# Altium schematic sheet CHIPHOME Y17BP01 Peripherals.SchDoc
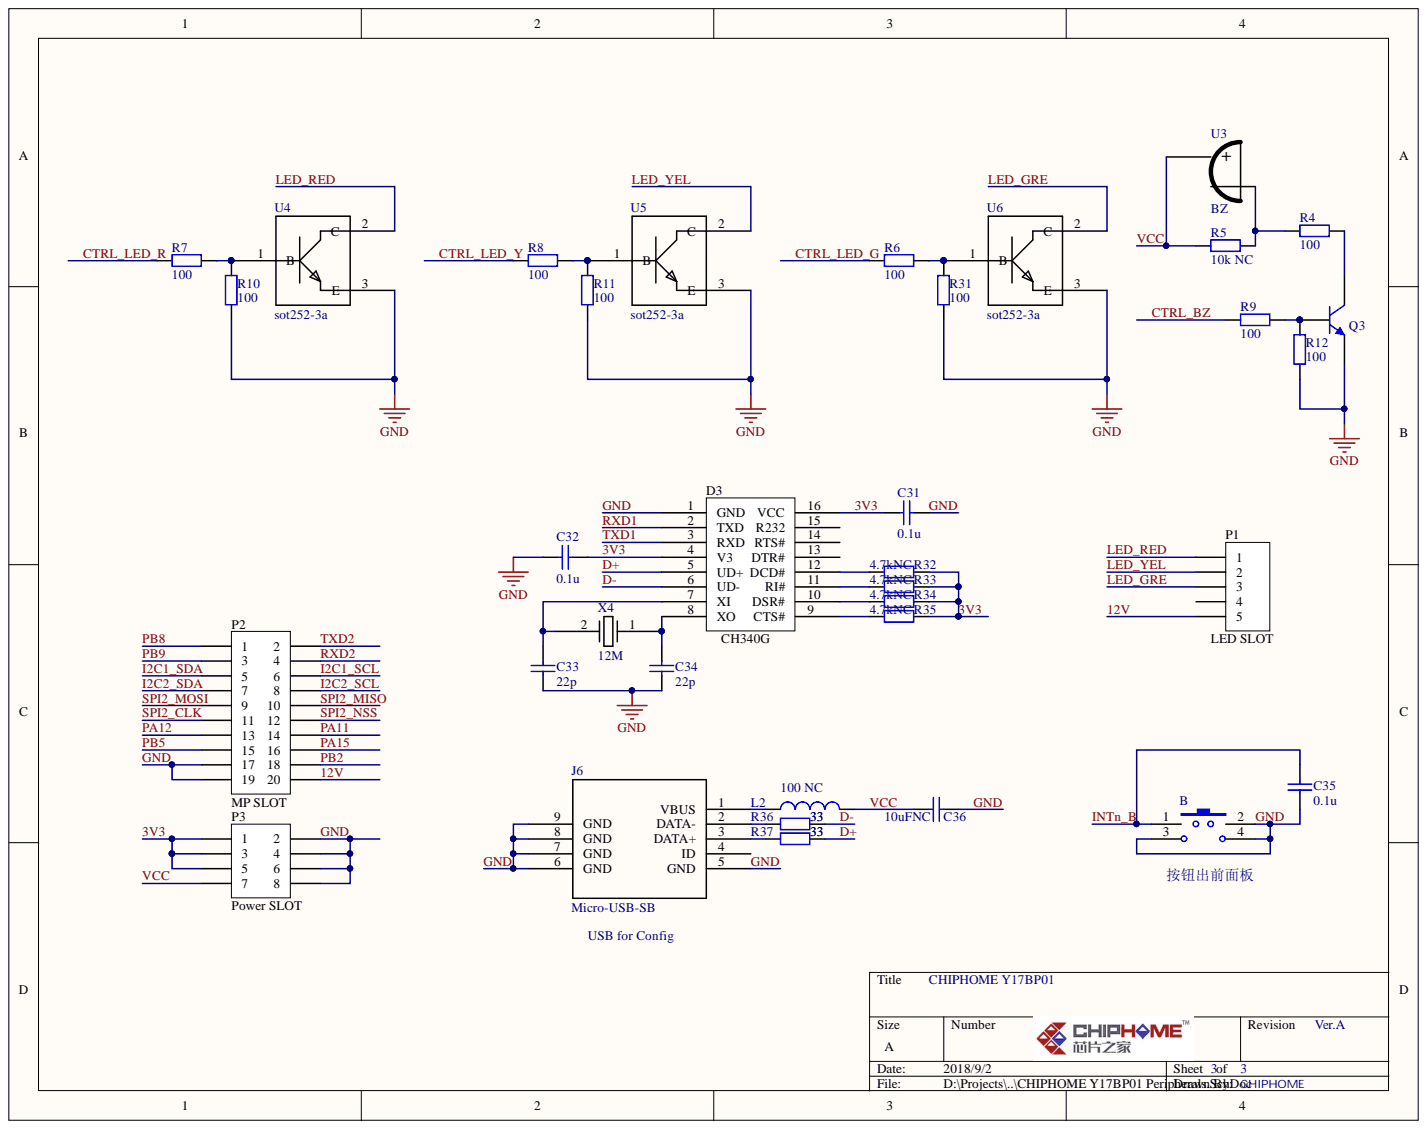
[diagram p0: CHIPHOME Y17BP01 Peripherals.SchDoc]

## Parts
B  switch-4p-dip-c  [switch-4p-dip-c]  (value not drawn)
C31  0.1u  [sc0603a]
C32  0.1u  [sc0603a]
C33  22p  [sc0603a]
C34  22p  [sc0603a]
C35  0.1u  [sc0603a]
C36  10uFNC  [sc0805a]
D3  CH340G  [sop16-50-062a]
J6  Micro-USB-SB  [susb5-0508a]
L2  100 NC  value 100  [sl0603a]
P1  LED SLOT  [sip5-100-H-0105a]
P2  MP SLOT  [HDR2X10]
P3  Power SLOT  [HDR2X4]
Q3  三极管  [SOT-23B_M]  (value not drawn)
R4  100  [sr0603a]
R5  10k NC  value 10k  [sr0603a]
R6  100  [sr0603a]
R7  100  [sr0603a]
R8  100  [sr0603a]
R9  100  [sr0603a]
R10  100  [sr0603a]
R11  100  [sr0603a]
R12  100  [sr0603a]
R31  100  [sr0603a]
R32  4.7kNC  [sr0603a]
R33  4.7kNC  [sr0603a]
R34  4.7kNC  [sr0603a]
R35  4.7kNC  [sr0603a]
R36  33  [L0603]
R37  33  [L0603]
U3  BZ  [buzzer2-065-12a]
U4  sot252-3a  [sot252-3a]
U5  sot252-3a  [sot252-3a]
U6  sot252-3a  [sot252-3a]
X4  12M  [sx2-0412a]

## Nets
3V3: C31.1 C32.2 D3.4(V3) D3.16(VCC) P3.1 P3.3 P3.5 R32.2 R33.2 R34.2 R35.2
12V: P1.5 P2.20
CTRL_BZ: R9.1
CTRL_LED_G: R6.1
CTRL_LED_R: R7.1
CTRL_LED_Y: R8.1
D+: D3.5(UD+) R37.2
D-: D3.6(UD-) R36.2
GND: B.2 B.3 B.4 C31.2 C32.1 C33.2 C34.2 C35.1 C36.1 D3.1(GND) J6.5(GND) J6.6(GND) J6.7(GND) J6.8(GND) J6.9(GND) P2.17 P2.19 P3.2 P3.4 P3.6 P3.8 Q3.2 R10.1 R11.1 R12.1 R31.1 U4.3 U5.3 U6.3
I2C1_SCL: P2.6
I2C1_SDA: P2.5
I2C2_SCL: P2.8
I2C2_SDA: P2.7
INTn_B: B.1 C35.2
LED_GRE: P1.3 U6.2
LED_RED: P1.1 U4.2
LED_YEL: P1.2 U5.2
PA11: P2.14
PA12: P2.13
PA15: P2.16
PB2: P2.18
PB5: P2.15
PB8: P2.1
PB9: P2.3
RXD1: D3.2(TXD)
RXD2: P2.4
SPI2_CLK: P2.11
SPI2_MISO: P2.10
SPI2_MOSI: P2.9
SPI2_NSS: P2.12
TXD1: D3.3(RXD)
TXD2: P2.2
VCC: C36.2 L2.2 P3.7 R5.2 U3.1
NetC33_1: C33.1 D3.7(XI) X4.2
NetC34_1: C34.1 D3.8(XO) X4.1
NetD3_9: D3.9(CTS#) R35.1
NetD3_10: D3.10(DSR#) R34.1
NetD3_11: D3.11(RI#) R33.1
NetD3_12: D3.12(DCD#) R32.1
NetJ6_1: J6.1(VBUS) L2.1
NetJ6_2: J6.2(DATA-) R36.1
NetJ6_3: J6.3(DATA+) R37.1
NetQ3_1: Q3.1 R9.2 R12.2
NetQ3_3: Q3.3 R4.2
NetR4_1: R4.1 R5.1 U3.2
NetR6_2: R6.2 R31.2 U6.1
NetR7_2: R7.2 R10.2 U4.1
NetR8_2: R8.2 R11.2 U5.1

Not connected: D3 13(DTR#) 14(RTS#) 15(R232); J6 4(ID); P1 4

## Library data of the parts
C31-C35 = Cap: Capacitor; Component Kind: Standard; LatestRevisionDate: 17-Jul-2002; LatestRevisionNote: Re-released for DXP Platform.; Library Name: Miscellaneous Devices.IntLib; PackageReference: RAD-0.3; Published: 8-Jun-2000; Signal Integrity: Cap; Simulation: CAP; Publisher: Altium Limited
  C31 C32 C35: Value: 0.1u
  C33 C34: Value: 22p
C36 = Cap: Capacitor; Value: 10uF; Component Kind: Standard; LatestRevisionDate: 17-Jul-2002; LatestRevisionNote: Re-released for DXP Platform.; Library Name: Miscellaneous Devices.IntLib; PackageReference: RAD-0.3; Published: 8-Jun-2000; Signal Integrity: Cap; Simulation: CAP; Publisher: Altium Limited
D3 = CH340: Component Kind: Standard; Library Name: MyLib.SchLib
J6 = Micro-USB-SB: Imported; CHcode: CNTSUW00001; Designer: Chiphome; Device: UB-MC5BR3-SD204-4S-1-TB NMP; Component Kind: Standard; Library Name: Micro-USB-SB.SchLib; RefDes: RefDes; Type: DEV; Value: Value
L2 = Inductor: Code_IEC: 1005; Code_JEITA: 0402; Component Kind: Standard; Description: Inductor; LatestRevisionDate: 29-May-2009; LatestRevisionNote: IPC-7351 Footprint Added.; Library Name: Miscellaneous Devices.IntLib; PackageDescription: Chip Inductor; PackageReference: 0402-A; Published: 8-Jun-2000; Signal Integrity: Inductor; Simulation: INDUCTOR; Publisher: Altium Limited
P1 = Header 5: Header, 5-Pin; Component Kind: Standard; LatestRevisionDate: 17-Jul-2002; LatestRevisionNote: Re-released for DXP Platform.; Library Name: Miscellaneous Connectors.IntLib; Signal Integrity: Connector; Publisher: Altium Limited
P2 = Header 10X2: Header, 10-Pin, Dual row; Component Kind: Standard; LatestRevisionDate: 17-Jul-2002; LatestRevisionNote: Re-released for DXP Platform.; Library Name: Miscellaneous Connectors.IntLib; Signal Integrity: Connector; Publisher: Altium Limited
P3 = Header 4X2: Header, 4-Pin, Dual row; Component Kind: Standard; LatestRevisionDate: 17-Jul-2002; LatestRevisionNote: Re-released for DXP Platform.; Library Name: Miscellaneous Connectors.IntLib; Signal Integrity: Connector; Publisher: Altium Limited
R4-R12 R31 R36 R37 = Res2: Resistor; Component Kind: Standard; LatestRevisionDate: 17-Jul-2002; LatestRevisionNote: Re-released for DXP Platform.; Library Name: Miscellaneous Devices.IntLib; PackageReference: AXIAL-0.4; Published: 8-Jun-2000; Signal Integrity: Res; Simulation: RESISTOR; Publisher: Altium Limited
  R4 R6-R12 R31: Value: 100
  R36 R37: Value: 33
R32-R35 = Res2: Resistor; Value: 4.7k; Component Kind: Standard; LatestRevisionDate: 17-Jul-2002; LatestRevisionNote: Re-released for DXP Platform.; Library Name: Miscellaneous Devices.IntLib; PackageReference: AXIAL-0.4; Published: 8-Jun-2000; Signal Integrity: Res; Simulation: RESISTOR; Publisher: Altium Limited
U3 = AT-1220-TT-9-R: Imported; CHcode: AUDBW000001; Designer: Chiphome; Component Kind: Standard; Device: AT-1220-TT-9-R; Library Name: AT-1220-TT-9-R.SchLib; RefDes: RefDes; Type: DEV; Value: Value
U4-U6 = sot252-3a: Imported; CHcode: DSTTW000003; Designer: Chiphome; Device: D882M; Component Kind: Standard; Library Name: D882M.SchLib; RefDes: RefDes; Type: DEV; Value: Value
X4 = ABL-12.000MHZ-B2: Imported; CHcode: XTLDP000001; Designer: Chiphome; Component Kind: Standard; Device: ABL-12.000MHZ-B2; Library Name: ABL-12.000MHZ-B2.SchLib; RefDes: RefDes; Type: DEV; Value: 12M
B = switch-4p-dip-c: Component Kind: Standard; Library Name: switch-4p-dip-c.SchLib
Q3 = 三极管: Component Kind: Standard; Library Name: MyLib.SchLib
